# Altium schematic sheet CurrentSensorBoard.SchDoc (continued)

## Library data of the parts
BNC1 = BNC: Manufacturer: TE Connectivity; Manufacturer Part Number: 5227699-1; Body Material: Zinc; Body Plating: Nickel; Frequency: 4GHz; Gender: Female; Impedance: 50R; Insulation Resistance: 5GR; Number of Positions: 1; PCB Mounting Orientation: Vertical; PCB Mount Retention: Without; Polarity: Standard; Sealable: No (Without); Voltage Rating: 500V; Component Kind: Standard; ComponentLink1Description: https://datasheet.ciiva.com/30491/0900766b8121e450-30491606.pdf?src-supplier=RSComponents; ComponentLink1URL: https://datasheet.ciiva.com/30491/0900766b8121e450-30491606.pdf?src-supplier=RSComponents; ComponentLink2Description: https://datasheet.ciiva.com/642/eng-cd-5227699-a1-642820.pdf?src-supplier=Digi-Key; ComponentLink2URL: https://datasheet.ciiva.com/642/eng-cd-5227699-a1-642820.pdf?src-supplier=Digi-Key; ComponentLink3Description: https://datasheet.ciiva.com/8044/1649653-8044086.pdf?src-supplier=Element14; ComponentLink3URL: https://datasheet.ciiva.com/8044/1649653-8044086.pdf?src-supplier=Element14; ComponentLink4Description: https://datasheet.ciiva.com/14565/70042557-14565526.pdf?src-supplier=Allied+Electronics; ComponentLink4URL: https://datasheet.ciiva.com/14565/70042557-14565526.pdf?src-supplier=Allied+Electronics; ComponentLink5Description: https://datasheet.ciiva.com/3131/eng-cs-1307191-bnc-connectors-0307-3131320.pdf?src-supplier=Avnet; ComponentLink5URL: https://datasheet.ciiva.com/3131/eng-cs-1307191-bnc-connectors-0307-3131320.pdf?src-supplier=Avnet; Connector Type: BNC; Contact Material: Bronze; Contact Plating: Silver; Depth: 17.45mm; Digikey Part no.: A33798-ND; ELV: Compliant; Fastening Type: Bayonet; Lead Free: Lead Free; Length: 17.45mm; Library Name: SensorCard.SchLib; Max Frequency: 4GHz; Max Operating Temperature: 85°C; Min Operating Temperature: -55°C; Mount: Through Hole; Orientation: Straight; Package Quantity: 30; Packaging: Rail/Tube; Pricing 1: 1=3.67000, 10=3.52000, 30=3.22700, 60=3.08017, 120=2.93358, 270=2.56685, 510=2.49353, 1020=2.12683, 2520=1.98015 (USD); Radiation Hardening: No; REACH SVHC: Unknown; RoHS: Yes; Stock 1: 1551; Supplier 1: Digi-Key; Supplier Part Number 1: A33798-ND; Termination: Solder
C1-C4 C9 = CMP-2006-03069-1: Manufacturer: Kemet; Dielectric: Y5V; Dielectric Material: Ceramic; Dissipation Factor: 7%; Tolerance: 80%; Voltage: 25V; Case/Package: 0603; Case Code (Imperial): 0603; Case Code (Metric): 1608; Component Kind: Standard; ComponentLink1Description: https://datasheet.ciiva.com/7823/1470417-7823994.pdf?src-supplier=Element14; ComponentLink1URL: https://datasheet.ciiva.com/7823/1470417-7823994.pdf?src-supplier=Element14; ComponentLink2Description: https://datasheet.ciiva.com/46443/1828802-46443708.pdf?src-supplier=Element14; ComponentLink2URL: https://datasheet.ciiva.com/46443/1828802-46443708.pdf?src-supplier=Element14; ComponentLink3Description: https://datasheet.ciiva.com/46443/1828802-46443709.pdf?src-supplier=Element14; ComponentLink3URL: https://datasheet.ciiva.com/46443/1828802-46443709.pdf?src-supplier=Element14; ComponentLink4Description: https://datasheet.ciiva.com/14589/70095383-14589007.pdf?src-supplier=Allied+Electronics; ComponentLink4URL: https://datasheet.ciiva.com/14589/70095383-14589007.pdf?src-supplier=Allied+Electronics; Depth: 0.8mm; Height: 0.8mm; Lead Free: Lead Free; Lead Pitch: 0.7mm; Length: 1.6mm; Library Name: Altium Content Vault; Manufacturer Part Number: C0603C104Z3VACTU; Max Operating Temperature: 85°C; Min Operating Temperature: -30°C; Mount: Surface Mount; Number of Pins: 2; Package Quantity: 1; Packaging: Tape and Reel; Part Number: C0603C104Z3VACTU; Pricing 1: 1=0.10000, 10=0.03500, 100=0.01560, 500=0.01114, 1000=0.00875 (USD); Radiation Hardening: No; REACH SVHC: No SVHC; RoHS: Yes; Stock 1: 1688362; Supplier 1: Digi-Key; Supplier Part Number 1: 399-1100-1-ND; Termination: SMD/SMT; Thickness: 0.034inch; Voltage Rating: 25V; Voltage Rating (DC): 25V; Width: 0.031inch
C5 = CMP-1662-00022-1: Chip Capacitor, 1 nF, +/- 5%, 50 V, -55 to 125 degC, 0603 (1608 Metric), RoHS, Tape and Reel; Manufacturer: Murata; Dielectric Material: Ceramic; Insulation Resistance: 10GR; Resistance: 100000000000 Ohm; Temperature Coefficient: 30ppm/°C; Voltage: 50V; Voltage Rating: 50 V; Case/Package: 0603; Case Code (Imperial): 0603; Case Code (Metric): 1608; Component Kind: Standard; ComponentLink1Description: Manufacturer URL; ComponentLink1URL: http://www.murata.com/; ComponentLink2Description: Datasheet; ComponentLink2URL: http://search.murata.co.jp/Ceramy/image/img/A01X/G101/ENG/GRM1885C1H102JA01-01.pdf; ComponentLink3Description: https://datasheet.ciiva.com/18184/getdatasheetpartid-153109-18184812.pdf?src-supplier=Verical; ComponentLink3URL: https://datasheet.ciiva.com/18184/getdatasheetpartid-153109-18184812.pdf?src-supplier=Verical; ComponentLink4Description: https://datasheet.ciiva.com/29149/450301-da-01-en-vs-kondensator-0603-29149020.pdf?src-supplier=Conrad; ComponentLink4URL: https://datasheet.ciiva.com/29149/450301-da-01-en-vs-kondensator-0603-29149020.pdf?src-supplier=Conrad; ComponentLink5Description: https://datasheet.ciiva.com/5421/product-5421450.pdf?src-supplier=Mouser; ComponentLink5URL: https://datasheet.ciiva.com/5421/product-5421450.pdf?src-supplier=Mouser; ComponentLink6Description: https://datasheet.ciiva.com/131/partnumbering-e-01-131963.pdf?src-supplier=Digi-Key; ComponentLink6URL: https://datasheet.ciiva.com/131/partnumbering-e-01-131963.pdf?src-supplier=Digi-Key; ComponentLink7Description: https://datasheet.ciiva.com/131/partnumbering-e-01-131964.pdf?src-supplier=Digi-Key; ComponentLink7URL: https://datasheet.ciiva.com/131/partnumbering-e-01-131964.pdf?src-supplier=Digi-Key; ComponentLink8Description: https://datasheet.ciiva.com/6072/4360-6072460.pdf?src-supplier=Farnell; ComponentLink8URL: https://datasheet.ciiva.com/6072/4360-6072460.pdf?src-supplier=Farnell; ComponentLink9Description: https://datasheet.ciiva.com/131/partnumbering-e-01-131965.pdf?src-supplier=Digi-Key; ComponentLink9URL: https://datasheet.ciiva.com/131/partnumbering-e-01-131965.pdf?src-supplier=Digi-Key; ComponentLink10Description: https://datasheet.ciiva.com/7828/1784402-7828893.pdf?src-supplier=Element14; ComponentLink10URL: https://datasheet.ciiva.com/7828/1784402-7828893.pdf?src-supplier=Element14; ComponentLink11Description: https://datasheet.ciiva.com/7828/1784402-7828894.pdf?src-supplier=Element14; ComponentLink11URL: https://datasheet.ciiva.com/7828/1784402-7828894.pdf?src-supplier=Element14; ComponentLink12Description: https://datasheet.ciiva.com/7828/4360-7828895.pdf?src-supplier=Element14; ComponentLink12URL: https://datasheet.ciiva.com/7828/4360-7828895.pdf?src-supplier=Element14; DatasheetVersion: Mar-16; Depth: 0.8mm; Height: 0.8 mm; Lead Free: Lead Free; Length: 1.6 mm; Library Name: Altium Content Vault; Manufacturer Part Number: GRM1885C1H102JA01D; Material: Ceramic; Max Operating Temperature: 125degC; Min Operating Temperature: -55degC; Mount: Surface Mount; Number of Pins: 2; PackageDescription: 2-Pin Surface Mount Device, Body 1.6 x 0.8 mm; Package Quantity: 4000; PackageReference: 0603; Packaging: Tape and Reel; Pins: 2; Pricing 1: 1=0.10000, 10=0.07000, 100=0.03300, 500=0.02314, 1000=0.01916 (USD); Radiation Hardening: No; REACH SVHC: No SVHC; RoHS: Yes; RoHSCompliant: True; Stock 1: 1837786; Supplier 1: Digi-Key; Supplier Part Number 1: 490-1451-1-ND; Termination: SMD/SMT; Thickness: 0.035inch; Tolerance: 5%; Type (Capacitors): C0G; Voltage Rating (DC): 50 V; Width: 0.8 mm
C6-C8 C10 C11 = Cap: Capacitor; Manufacturer: Samsung; Manufacturer Part Number: CL21A106KAFN3NE; Tolerance: 10%; Voltage Rating: 25V; Case/Package: 0805; Case Code (Imperial): 0805; Case Code (Metric): 2012; Component Kind: Standard; ComponentLink1Description: https://datasheet.ciiva.com/25720/cl21a106kafn3ne-25720275.pdf?src-supplier=Digi-Key; ComponentLink1URL: https://datasheet.ciiva.com/25720/cl21a106kafn3ne-25720275.pdf?src-supplier=Digi-Key; Lead Free: Lead Free; Length: 0.079inch; Library Name: SensorCard.SchLib; Max Operating Temperature: 85°C; Min Operating Temperature: -55°C; Mount: Surface Mount; Package Quantity: 1; Packaging: Cut Tape; Pricing 1: 1=0.28000, 10=0.19300, 100=0.09770, 500=0.06684, 1000=0.05912 (USD); RoHS: Yes; Stock 1: 51388; Supplier 1: Digi-Key; Supplier Part Number 1: 1276-2890-1-ND; Thickness: 0.053inch; Width: 0.049inch
DS1 = CMP-2000-06622-1: LED Green, 75 mW, 80 mA, -55 to 85 degC, 2-Pin SMD, RoHS, Tape and Reel; Manufacturer: Lite-On; Dominant Wavelength: 576.5nm; Forward (Drive) Current: 5mA; Forward Current: 30mA; Forward Voltage: 2.3V; Intensity: 28mcd; Lens Color: Colorless; Lens Style: Diffused; Lens Transparency: Clear; Number of Elements: 1; Power Dissipation: 75mW; Reverse Voltage: 5V; Viewing Angle: 130°; Case/Package: 0603; Case Code (Imperial): 0603; Case Code (Metric): 1608; Color: Green; Component Kind: Standard; ComponentLink1Description: https://datasheet.ciiva.com/12400/ltst-c193-series-201756-12400795.pdf?src-supplier=Mouser; ComponentLink1URL: https://datasheet.ciiva.com/12400/ltst-c193-series-201756-12400795.pdf?src-supplier=Mouser; ComponentLink2Description: https://datasheet.ciiva.com/11773/0900766b80dc0550-11773612.pdf?src-supplier=RSComponents; ComponentLink2URL: https://datasheet.ciiva.com/11773/0900766b80dc0550-11773612.pdf?src-supplier=RSComponents; ComponentLink3Description: https://datasheet.ciiva.com/16444/ltst-c193kgkt-5a-16444759.pdf?src-supplier=Future+Electronics; ComponentLink3URL: https://datasheet.ciiva.com/16444/ltst-c193kgkt-5a-16444759.pdf?src-supplier=Future+Electronics; ComponentLink4Description: https://datasheet.ciiva.com/2110/s-110-ltst-c193kgkt-5a-2110267.pdf?src-supplier=Digi-Key; ComponentLink4URL: https://datasheet.ciiva.com/2110/s-110-ltst-c193kgkt-5a-2110267.pdf?src-supplier=Digi-Key; ComponentLink5Description: https://datasheet.ciiva.com/2110/s-110-ltst-c193kgkt-5a-2110269.pdf?src-supplier=Digi-Key; ComponentLink5URL: https://datasheet.ciiva.com/2110/s-110-ltst-c193kgkt-5a-2110269.pdf?src-supplier=Digi-Key; ComponentLink6Description: https://datasheet.ciiva.com/2110/s-110-ltst-c193kgkt-5a-2110268.pdf?src-supplier=Digi-Key; ComponentLink6URL: https://datasheet.ciiva.com/2110/s-110-ltst-c193kgkt-5a-2110268.pdf?src-supplier=Digi-Key; Datasheet URL: http://optoelectronics.liteon.com/upload/download/DS22-2007-0094/S_110_LTST-C193KGKT-5A.pdf; Datasheet Version: 03/2007; Depth: 0.8mm; Dimension: 1.6x0.8x0.35mm; Height: 0.35mm; Illumination Color: Green; Lead Free: Lead Free; Length: 1.6mm; Lens Type: Clear; Library Name: Altium Content Vault; Luminous Intensity: 28mcd; Manufacturer Part Number: LTST-C193KGKT-5A; Manufacturer URL: http://www.us.liteon.com/; Max Operating Temperature: 85°C; Min Operating Temperature: -55°C; Mount: Surface Mount; Mounting Technology: SurfaceMount; Number of LEDs: 1; Number of Pins: 2; Package Description: 2-Pin Surface Mount Device, Body 1.6 x 0.8 mm; Package Quantity: 3000; Package Reference: C193KGKT-5A; Packaging: Tape and Reel; Power Rating: 75mW; Pricing 1: 1=0.41000, 10=0.29600, 25=0.22680, 100=0.14800, 250=0.10852, 500=0.09210, 1000=0.07565, 2500=0.06578 (USD); Radiation Hardening: No; RoHS: Yes; Stock 1: 98599; Supplier 1: Digi-Key; Supplier Part Number 1: 160-1828-1-ND; Test Current: 5mA; Width: 0.8mm
DS2 = CMP-2000-06624-1: LED Uni-Color Blue, 76 mW, 20 mA, -20 to 80 degC, 2-Pin SMD, RoHS, Tape and Reel; Brand: Lite-On; Dominant Wavelength: 475nm; Forward Current: 5mA; Forward Voltage: 2.8V; LED Size: 1.6mmx0.8mmx0.35mm; Lens Color: Colorless; Lens Style: Diffused; Lens Transparency: Clear; Luminous Intensity: 15mcd; Number of Elements: 1; Power Dissipation: 76mW; Reverse Voltage: 5V; Viewing Angle: 130deg; Wavelength/Color Temperature: 465nmto475nm; Case/Package: 0603; Case Code (Imperial): 0603; Case Code (Metric): 1608; Color: Blue; Component Kind: Standard; ComponentLink1Description: https://datasheet.ciiva.com/4688/lite-on-ltst-c193tbkt-5a-187386-4688832.pdf?src-supplier=Mouser; ComponentLink1URL: https://datasheet.ciiva.com/4688/lite-on-ltst-c193tbkt-5a-187386-4688832.pdf?src-supplier=Mouser; ComponentLink2Description: https://datasheet.ciiva.com/2111/ltst-c193tbkt-5a-2111219.pdf?src-supplier=Digi-Key; ComponentLink2URL: https://datasheet.ciiva.com/2111/ltst-c193tbkt-5a-2111219.pdf?src-supplier=Digi-Key; ComponentLink3Description: https://datasheet.ciiva.com/15201/getdatasheetpartid-293056-15201395.pdf?src-supplier=Verical; ComponentLink3URL: https://datasheet.ciiva.com/15201/getdatasheetpartid-293056-15201395.pdf?src-supplier=Verical; ComponentLink4Description: https://datasheet.ciiva.com/11773/0900766b80dc0554-11773880.pdf?src-supplier=RSComponents; ComponentLink4URL: https://datasheet.ciiva.com/11773/0900766b80dc0554-11773880.pdf?src-supplier=RSComponents; ComponentLink5Description: https://datasheet.ciiva.com/16210/ltst-c193tbkt-5a-16210824.pdf?src-supplier=Future+Electronics; ComponentLink5URL: https://datasheet.ciiva.com/16210/ltst-c193tbkt-5a-16210824.pdf?src-supplier=Future+Electronics; ComponentLink6Description: https://datasheet.ciiva.com/2111/p-100-ltst-c193tbkt-5a-2111220.pdf?src-supplier=Digi-Key; ComponentLink6URL: https://datasheet.ciiva.com/2111/p-100-ltst-c193tbkt-5a-2111220.pdf?src-supplier=Digi-Key; ComponentLink7Description: https://datasheet.ciiva.com/2111/p-100-ltst-c193tbkt-5a-2111221.pdf?src-supplier=Digi-Key; ComponentLink7URL: https://datasheet.ciiva.com/2111/p-100-ltst-c193tbkt-5a-2111221.pdf?src-supplier=Digi-Key; Datasheet URL: https://datasheet.ciiva.com/15201/getdatasheetpartid-293056-15201395.pdf; Datasheet Version: 08/2011; Depth: 0.8mm; Factory Pack Quantity: 3000; Height: 0.35mm; Illumination Color: Blue; Lead Free: Lead Free; Length: 1.6mm; Library Name: Altium Content Vault; Manufacturer: Lite-On; Manufacturer Part Number: LTST-C193TBKT-5A; Manufacturer URL: http://www.us.liteon.com/; Maximum Operating Temperature: +80C; Max Operating Temperature: 80°C; Minimum Operating Temperature: -20C; Min Operating Temperature: -20°C; Mount: Surface Mount; Mounting Technology: SurfaceMount; Number of LEDs: 1; Number of Pins: 2; Package / Case: 0603; Package Description: 2-Pin Surface Mount Device, Body 0.8 x 1.6 mm; Package Quantity: 1; Package Reference: C193TBKT; Packaging: Reel; Pricing 1: 1=0.47000, 10=0.33600, 25=0.25720, 100=0.16780, 250=0.12304, 500=0.10442, 1000=0.08577, 2500=0.07458 (USD); Product Category: StandardLEDs-SMD; Radiation Hardening: No; RoHS: Details; Stock 1: 234360; Supplier 1: Digi-Key; Supplier Part Number 1: 160-1827-1-ND; Test Current: 5mA; Width: 0.8mm
L1-L4 = CMP-0220-00193-1: SMD EMI Suppression Ferrite Bead WE-CBF, Z = 30 Ohm; Manufacturer: Wurth Electronics; Current Rating: 1A; DC Resistance (DCR): 40mR; Impedance: 30 Ohm; Inductance: 5.6H; Max Current Rating: 3A; Number of Lines: 1; Test Frequency: 100MHz; Tolerance: 25%; Case/Package: SMD/SMT; Case Code (Imperial): 0603; Case Code (Metric): 1608; Component Kind: Standard; ComponentLink1Description: Manufacturer Link; ComponentLink1URL: http://www.we-online.com; ComponentLink2Description: Datasheet; ComponentLink2URL: http://www.we-online.de/katalog/media/pdf/742792609.pdf; ComponentLink3Description: https://datasheet.ciiva.com/22711/1696069-22711408.pdf?src-supplier=Element14; ComponentLink3URL: https://datasheet.ciiva.com/22711/1696069-22711408.pdf?src-supplier=Element14; ComponentLink4Description: https://datasheet.ciiva.com/1013/742792609-1013542.pdf?src-supplier=Digi-Key; ComponentLink4URL: https://datasheet.ciiva.com/1013/742792609-1013542.pdf?src-supplier=Digi-Key; ComponentLink5Description: https://datasheet.ciiva.com/4940/742792609-79267-4940428.pdf?src-supplier=Mouser; ComponentLink5URL: https://datasheet.ciiva.com/4940/742792609-79267-4940428.pdf?src-supplier=Mouser; ComponentLink6Description: https://datasheet.ciiva.com/14634/0900766b80d2ddc1-14634954.pdf?src-supplier=Allied+Electronics; ComponentLink6URL: https://datasheet.ciiva.com/14634/0900766b80d2ddc1-14634954.pdf?src-supplier=Allied+Electronics; ComponentLink7Description: https://datasheet.ciiva.com/11799/0900766b80d2ddc1-11799675.pdf?src-supplier=RSComponents; ComponentLink7URL: https://datasheet.ciiva.com/11799/0900766b80d2ddc1-11799675.pdf?src-supplier=RSComponents; ComponentLink8Description: https://datasheet.ciiva.com/23762/742792609-23762991.pdf?src-supplier=Newark; ComponentLink8URL: https://datasheet.ciiva.com/23762/742792609-23762991.pdf?src-supplier=Newark; ComponentLink9Description: https://datasheet.ciiva.com/1466/1696069-1466420.pdf?src-supplier=Element14; ComponentLink9URL: https://datasheet.ciiva.com/1466/1696069-1466420.pdf?src-supplier=Element14; ComponentLink10Description: https://datasheet.ciiva.com/1013/742792609-1013543.pdf?src-supplier=Digi-Key; ComponentLink10URL: https://datasheet.ciiva.com/1013/742792609-1013543.pdf?src-supplier=Digi-Key; ComponentLink11Description: https://datasheet.ciiva.com/1013/742792609-1013544.pdf?src-supplier=Digi-Key; ComponentLink11URL: https://datasheet.ciiva.com/1013/742792609-1013544.pdf?src-supplier=Digi-Key; Core Material: Ferrite; DatasheetVersion: Dec-2005; Depth: 0.8mm; Height: 0.8mm; Length: 1.6mm; Library Name: Altium Content Vault; Manufacturer Part Number: 742792609; Material: Ferrite; Max DC Current: 3A; Max Operating Temperature: 125°C; Min Operating Temperature: -55°C; Mount: Surface Mount; PackageDescription: Chip Inductor, 2-Leads, Body 1.6 x 0.8 mm; Package Quantity: 1; PackageReference: SMD-0603; PackageVersion: 2009; Packaging: Tape and Reel; Pricing 1: 1=0.17000, 50=0.16800, 100=0.15500, 500=0.14800, 1000=0.14100, 2000=0.13400 (USD); REACH SVHC: Unknown; Resistance: 40mR; RoHS: Yes; Stock 1: 70099; Supplier 1: Digi-Key; Supplier Part Number 1: 732-1591-1-ND; Termination: SMD/SMT; Weight: 0.000141oz; Width: 0.8mm
MH1 MH2 = MOUNT_HOLE: MOUNTING HOLE; Component Kind: Standard; Library Name: SensorCard.SchLib
P1 = HDR1x3: Manufacturer: TE Connectivity; Manufacturer Part Number: 282834-3; Approvals: UL; Closed End: No (Without); Connector Type: Receptacle; Current: 10A; Max Supply Voltage (AC): 150V; Number of Circuits: 3; Number of Levels: 1; Pitch: 2.54mm; Plating: Brass; Screwless Terminal Block: Yes (With); Tail Length: 3.4mm; Thread Size: M1.6; Wire/Cable Gauge: 30AWG; Color: Green; Component Kind: Standard; ComponentLink1Description: https://datasheet.ciiva.com/11757/0900766b80f924bc-11757569.pdf?src-supplier=RSComponents; ComponentLink1URL: https://datasheet.ciiva.com/11757/0900766b80f924bc-11757569.pdf?src-supplier=RSComponents; ComponentLink2Description: https://datasheet.ciiva.com/15169/getdatasheetpartid-155865-15169559.pdf?src-supplier=Verical; ComponentLink2URL: https://datasheet.ciiva.com/15169/getdatasheetpartid-155865-15169559.pdf?src-supplier=Verical; ComponentLink3Description: https://datasheet.ciiva.com/30217/eng-cs-1308389-eurostyle-terminal-blocks-0607-30217495.pdf?src-supplier=Onlinecomponents.com; ComponentLink3URL: https://datasheet.ciiva.com/30217/eng-cs-1308389-eurostyle-terminal-blocks-0607-30217495.pdf?src-supplier=Onlinecomponents.com; ComponentLink4Description: https://datasheet.ciiva.com/823/eng-cd-282834-c1-823464.pdf?src-supplier=Digi-Key; ComponentLink4URL: https://datasheet.ciiva.com/823/eng-cd-282834-c1-823464.pdf?src-supplier=Digi-Key; ComponentLink5Description: https://datasheet.ciiva.com/14610/70087777-14610266.pdf?src-supplier=Allied+Electronics; ComponentLink5URL: https://datasheet.ciiva.com/14610/70087777-14610266.pdf?src-supplier=Allied+Electronics; ComponentLink6Description: https://datasheet.ciiva.com/3141/eng-cs-1308389-eurostyle-terminal-blocks-0607-3141116.pdf?src-supplier=Avnet; ComponentLink6URL: https://datasheet.ciiva.com/3141/eng-cs-1308389-eurostyle-terminal-blocks-0607-3141116.pdf?src-supplier=Avnet; ComponentLink7Description: https://datasheet.ciiva.com/16469/eng-cs-1308389-eurostyle-terminal-blocks-0607-16469502.pdf?src-supplier=Avnet+Asia; ComponentLink7URL: https://datasheet.ciiva.com/16469/eng-cs-1308389-eurostyle-terminal-blocks-0607-16469502.pdf?src-supplier=Avnet+Asia; ComponentLink8Description: https://datasheet.ciiva.com/7978/583107-7978559.pdf?src-supplier=Element14; ComponentLink8URL: https://datasheet.ciiva.com/7978/583107-7978559.pdf?src-supplier=Element14; Contact Material: Brass; Contact Plating: Tin; Current Rating: 10A; Depth: 6.5mm; ELV: Compliant; Flammability Rating: UL94 V-0; Housing Color: Green; Housing Material: Polyamide; Interlock: No (Without); Lead Free: Lead Free; Length: 8.08mm; Library Name: SensorCard.SchLib; Max Current Rating: 10A; Max Operating Temperature: 105°C; Max Voltage Rating (AC): 150V; Min Operating Temperature: -40°C; Mount: Through Hole; Number of Contacts: 3; Number of Poles: 3; Number of Positions: 3; Number of Positions Per Level: 3; Number of Rows: 1; Orientation: Straight; Package Quantity: 200; Packaging: Bulk; Pricing 1: 1=2.01000, 10=1.52300, 25=1.41160, 50=1.26920, 100=1.23820, 250=1.08340, 500=0.99052, 1000=0.89767, 5000=0.78933 (USD); Radiation Hardening: No; REACH SVHC: Unknown; RoHS: Yes; Screw Flange: No (Without); Side Stackable: Yes (With); Stock 1: 4635; Supplier 1: Digi-Key; Supplier Part Number 1: A98334-ND; Termination: Solder; Voltage: 150V; Voltage Rating: 150V; Width: 10mm; Wire Gauge (Max): 30AWG; Wire Gauge (Min): 30AWG
P2 = HDR1X7: Manufacturer: TE Connectivity; Manufacturer Part Number: 5-534237-5; Approvals: CSA; Boss: No (Without); Connector Type: Receptacle; Contact Current Rating: 2A; Contact Post Length: 2.92mm; Contact Resistance: 12MR; High Temperature Compatible: No; Insulation Resistance: 5GR; Number of Contacts: 7; PCB Mounting Orientation: Vertical; PCB Mount Retention: Without; PCB Thickness: 2.4mm; Pitch: 2.54mm; Row Spacing: 0.1inch; Stackable: Yes; Voltage Rating: 750V; Color: Black; Component Kind: Standard; ComponentLink1Description: https://datasheet.ciiva.com/16318/eng-cs-1307819-section-5-0908-16318099.pdf?src-supplier=Future+Electronics; ComponentLink1URL: https://datasheet.ciiva.com/16318/eng-cs-1307819-section-5-0908-16318099.pdf?src-supplier=Future+Electronics; ComponentLink2Description: https://datasheet.ciiva.com/15175/getdatasheetpartid-36747-15175462.pdf?src-supplier=Verical; ComponentLink2URL: https://datasheet.ciiva.com/15175/getdatasheetpartid-36747-15175462.pdf?src-supplier=Verical; ComponentLink3Description: https://datasheet.ciiva.com/746/eng-cd-534237-r5-746279.pdf?src-supplier=Digi-Key; ComponentLink3URL: https://datasheet.ciiva.com/746/eng-cd-534237-r5-746279.pdf?src-supplier=Digi-Key; ComponentLink4Description: https://datasheet.ciiva.com/9479/eng-ds-1307819-ampmodu-0811-9479736.pdf?src-supplier=Avnet; ComponentLink4URL: https://datasheet.ciiva.com/9479/eng-ds-1307819-ampmodu-0811-9479736.pdf?src-supplier=Avnet; ComponentLink5Description: https://datasheet.ciiva.com/30056/eng-ds-1307819-ampmodu-0811-30056440.pdf?src-supplier=Onlinecomponents.com; ComponentLink5URL: https://datasheet.ciiva.com/30056/eng-ds-1307819-ampmodu-0811-30056440.pdf?src-supplier=Onlinecomponents.com; Contact Material: Bronze; Contact Plating: Gold; Contact Shape: Square; Current Rating: 2A; Depth: 3.56mm; ELV: Compliant; Flammability Rating: UL94 V-0; Gender: Receptacle; Height: 3.56mm; Housing Color: Black; Housing Material: Polyester; Lead Free: Lead Free; Length: 17.78mm; Library Name: SensorCard.SchLib; Max Operating Temperature: 105°C; Min Operating Temperature: -65°C; Mount: Through Hole; Number of Positions: 7; Number of Rows: 1; Orientation: Straight; Package Quantity: 32; Packaging: Rail/Tube; Pricing 1: 1=2.35000, 32=2.00094, 128=1.82703, 512=1.52250, 1024=1.30500, 5024=1.15710 (USD); Radiation Hardening: No; REACH SVHC: Unknown; RoHS: Yes; Sealable: No (Without); Stock 1: 2592; Supplier 1: Digi-Key; Supplier Part Number 1: A32908-ND; Tail Length: 2.92mm; Termination: Solder
P3 = HDR1x3: Manufacturer: TE Connectivity; Manufacturer Part Number: 215297-3; Connector Type: Receptacle; Contact Current Rating: 3A; Contact Post Length: 3.1mm; Contact Resistance: 12mR; Number of Contacts: 3; PCB Mounting Orientation: Vertical; PCB Mount Retention: With; PCB Thickness: 1.6mm; Pitch: 2.54mm; Sealable: No (Without); Stackable: Yes; Color: Green; Component Kind: Standard; ComponentLink1Description: https://datasheet.ciiva.com/32373/2152973-32373113.pdf?src-supplier=Onlinecomponents.com; ComponentLink1URL: https://datasheet.ciiva.com/32373/2152973-32373113.pdf?src-supplier=Onlinecomponents.com; ComponentLink2Description: https://datasheet.ciiva.com/11748/0900766b805762f6-11748764.pdf?src-supplier=RSComponents; ComponentLink2URL: https://datasheet.ciiva.com/11748/0900766b805762f6-11748764.pdf?src-supplier=RSComponents; ComponentLink3Description: https://datasheet.ciiva.com/8003/525120-8003961.pdf?src-supplier=Element14; ComponentLink3URL: https://datasheet.ciiva.com/8003/525120-8003961.pdf?src-supplier=Element14; ComponentLink4Description: https://datasheet.ciiva.com/6857/eng-cd-215297-d-basefilename-6857450.pdf?src-supplier=Digi-Key; ComponentLink4URL: https://datasheet.ciiva.com/6857/eng-cd-215297-d-basefilename-6857450.pdf?src-supplier=Digi-Key; ComponentLink5Description: https://datasheet.ciiva.com/1439/1651969-1439469.pdf?src-supplier=Farnell; ComponentLink5URL: https://datasheet.ciiva.com/1439/1651969-1439469.pdf?src-supplier=Farnell; ComponentLink6Description: https://datasheet.ciiva.com/14120/215297serie-eng-tds-14120829.pdf?src-supplier=Distrelec; ComponentLink6URL: https://datasheet.ciiva.com/14120/215297serie-eng-tds-14120829.pdf?src-supplier=Distrelec; Contact Gender: Female; Contact Material: Bronze; Contact Plating: Gold; Contact Shape: Square; Current Rating: 3A; Depth: 2.52mm; ELV: Compliant; Flammability Rating: UL94 V-0; Gender: Receptacle; Height: 7mm; Housing Color: Black; Housing Material: Polyester; Length: 7.62mm; Library Name: SensorCard.SchLib; Max Operating Temperature: 105°C; Min Operating Temperature: -55°C; Mount: Through Hole; Number of Positions: 3; Number of Rows: 1; Orientation: Straight; Package Quantity: 240; Packaging: Tray; Pricing 1: 1=0.81000, 10=0.71400, 100=0.61600, 500=0.50400, 1000=0.44800, 5000=0.39200, 10000=0.37240 (USD); RoHS: Yes; Stock 1: 7420; Supplier 1: Digi-Key; Supplier Part Number 1: A106650-ND; Tail Length: 3.1mm; Termination: Solder
P5 P6 = Screw terminal: 6 Pin Screw Terminal, Power Tap 10-32 Through Hole; Manufacturer: Keystone Electronics; Manufacturer Part Number: 8196; Connector Type: PCB; Current Rating: 30A; Gender: Female; Insulation: Non-Insulated; Number of Contacts: 6; Number of Ports: 1; Wire Gauge (Max): 12AWG; Wire Gauge (Min): 22AWG; Component Kind: Standard; ComponentLink1Description: https://datasheet.ciiva.com/16216/m60-2p63-16216147.pdf?src-supplier=Future+Electronics; ComponentLink1URL: https://datasheet.ciiva.com/16216/m60-2p63-16216147.pdf?src-supplier=Future+Electronics; ComponentLink2Description: https://datasheet.ciiva.com/13540/8196-290223-13540281.pdf?src-supplier=Mouser; ComponentLink2URL: https://datasheet.ciiva.com/13540/8196-290223-13540281.pdf?src-supplier=Mouser; ComponentLink3Description: https://datasheet.ciiva.com/22649/1910515-22649575.pdf?src-supplier=Element14; ComponentLink3URL: https://datasheet.ciiva.com/22649/1910515-22649575.pdf?src-supplier=Element14; ComponentLink4Description: https://datasheet.ciiva.com/14551/70181563-14551004.pdf?src-supplier=Allied+Electronics; ComponentLink4URL: https://datasheet.ciiva.com/14551/70181563-14551004.pdf?src-supplier=Allied+Electronics; Contact Material: Brass; Contact Plating: Tin; Depth: 11.93mm; Height: 0.679inch; Lead Free: Lead Free; Length: 11.93mm; Library Name: SensorCard.SchLib; Material: Brass; Max Current Rating: 30A; Mount: Through Hole; Number of Pins: 6; Number of Rows: 2; Number of Terminals: 6; Orientation: Straight; Package Quantity: 10; Packaging: Bulk; Pricing 1: 1=0.89000, 10=0.78100, 50=0.70400, 100=0.69840, 250=0.61220, 1000=0.54860, 2500=0.45920, 5000=0.42854 (USD); REACH SVHC: No SVHC; RoHS: Yes; Stock 1: 45944; Supplier 1: Digi-Key; Supplier Part Number 1: 36-8196-ND; Terminal Material: Brass; Termination: Screw
R1 R3 = CMP-2000-00767-1: Manufacturer: Panasonic; Manufacturer Part Number: ERJ3GEYJ302V; Max Power Dissipation: 100mW; Temperature Coefficient: 200ppm/°C; Tolerance: 5%; Voltage Rating: 75V; Case/Package: 0603; Case Code (Imperial): 0603; Case Code (Metric): 1608; Component Kind: Standard; ComponentLink1Description: https://datasheet.ciiva.com/11821/0900766b80f806dd-11821475.pdf?src-supplier=RSComponents; ComponentLink1URL: https://datasheet.ciiva.com/11821/0900766b80f806dd-11821475.pdf?src-supplier=RSComponents; ComponentLink2Description: https://datasheet.ciiva.com/24027/aoa0000ce1-37422-24027397.pdf?src-supplier=Mouser; ComponentLink2URL: https://datasheet.ciiva.com/24027/aoa0000ce1-37422-24027397.pdf?src-supplier=Mouser; ComponentLink3Description: https://datasheet.ciiva.com/43460/1500035-43460725.pdf?src-supplier=Farnell; ComponentLink3URL: https://datasheet.ciiva.com/43460/1500035-43460725.pdf?src-supplier=Farnell; ComponentLink4Description: https://datasheet.ciiva.com/9196/aoa0000ce1-9196743.pdf?src-supplier=Avnet; ComponentLink4URL: https://datasheet.ciiva.com/9196/aoa0000ce1-9196743.pdf?src-supplier=Avnet; ComponentLink5Description: https://datasheet.ciiva.com/16786/70255313-16786823.pdf?src-supplier=Allied+Electronics; ComponentLink5URL: https://datasheet.ciiva.com/16786/70255313-16786823.pdf?src-supplier=Allied+Electronics; ComponentLink6Description: https://datasheet.ciiva.com/9196/aoa0000ce1-9196746.pdf?src-supplier=Avnet; ComponentLink6URL: https://datasheet.ciiva.com/9196/aoa0000ce1-9196746.pdf?src-supplier=Avnet; ComponentLink7Description: https://datasheet.ciiva.com/46386/1500035-46386731.pdf?src-supplier=Element14; ComponentLink7URL: https://datasheet.ciiva.com/46386/1500035-46386731.pdf?src-supplier=Element14; ComponentLink8Description: https://datasheet.ciiva.com/30196/4aoa0000ce1-30196300.pdf?src-supplier=Onlinecomponents.com; ComponentLink8URL: https://datasheet.ciiva.com/30196/4aoa0000ce1-30196300.pdf?src-supplier=Onlinecomponents.com; ComponentLink9Description: https://datasheet.ciiva.com/28565/1678796-28565150.pdf?src-supplier=Element14; ComponentLink9URL: https://datasheet.ciiva.com/28565/1678796-28565150.pdf?src-supplier=Element14; ComponentLink10Description: https://datasheet.ciiva.com/28691/1678796-28691966.pdf?src-supplier=Element14; ComponentLink10URL: https://datasheet.ciiva.com/28691/1678796-28691966.pdf?src-supplier=Element14; Composition: Thick Film; Contact Plating: Tin; Depth: 0.8mm; Features: Automotive AEC-Q200; Height: 0.45mm; Length: 1.6mm; Library Name: Altium Content Vault; Max Operating Temperature: 155°C; Military Standard: Not; Min Operating Temperature: -55°C; Mount: Surface Mount; Number of Terminations: 2; Package Quantity: 1; Packaging: Tape and Reel; Part Number: ERJ-3GEYJ302V; Power Rating: 100mW; Pricing 1: 1=0.10000, 10=0.04300, 100=0.01760, 1000=0.00791, 2500=0.00686 (USD); REACH SVHC: No SVHC; RoHS: Yes; Stock 1: 269975; Supplier 1: Digi-Key; Supplier Part Number 1: P3.0KGCT-ND; Termination: SMD/SMT; Width: 0.031inch
R2 = CMP-1659-00035-1: General Purpose Chip Resistor, 470 Ohm, +/- 1%, -55 to 155 degC, 0603 (1608 Metric), RoHS, Tape and Reel; Manufacturer: Yageo; Max Power Dissipation: 100mW; Resistance: 470; Temperature Coefficient: 100ppm/°C; Voltage Rating: 50V; Working Voltage: 75V; Case/Package: 0603; Case Code (Imperial): 0603; Case Code (Metric): 1608; Component Kind: Standard; ComponentLink1Description: Manufacturer URL; ComponentLink1URL: http://www.yageo.com/; ComponentLink2Description: Datasheet; ComponentLink2URL: https://datasheet.ciiva.com/11808/0900766b80f96399-11808177.pdf; ComponentLink3Description: https://datasheet.ciiva.com/11808/0900766b80f96399-11808177.pdf?src-supplier=RSComponents; ComponentLink3URL: https://datasheet.ciiva.com/11808/0900766b80f96399-11808177.pdf?src-supplier=RSComponents; ComponentLink4Description: https://datasheet.ciiva.com/7746/1738695-7746359.pdf?src-supplier=Element14; ComponentLink4URL: https://datasheet.ciiva.com/7746/1738695-7746359.pdf?src-supplier=Element14; ComponentLink5Description: https://datasheet.ciiva.com/7746/1738695-7746360.pdf?src-supplier=Element14; ComponentLink5URL: https://datasheet.ciiva.com/7746/1738695-7746360.pdf?src-supplier=Element14; ComponentLink6Description: https://datasheet.ciiva.com/7660/1868787-7660793.pdf?src-supplier=Element14; ComponentLink6URL: https://datasheet.ciiva.com/7660/1868787-7660793.pdf?src-supplier=Element14; ComponentLink7Description: https://datasheet.ciiva.com/46440/1868787-46440907.pdf?src-supplier=Element14; ComponentLink7URL: https://datasheet.ciiva.com/46440/1868787-46440907.pdf?src-supplier=Element14; ComponentLink8Description: https://datasheet.ciiva.com/2454/pyu-r-int-thick-7-2454012.pdf?src-supplier=Digi-Key; ComponentLink8URL: https://datasheet.ciiva.com/2454/pyu-r-int-thick-7-2454012.pdf?src-supplier=Digi-Key; ComponentLink9Description: https://datasheet.ciiva.com/2454/pyu-r-int-thick-7-2454013.pdf?src-supplier=Digi-Key; ComponentLink9URL: https://datasheet.ciiva.com/2454/pyu-r-int-thick-7-2454013.pdf?src-supplier=Digi-Key; ComponentLink10Description: https://datasheet.ciiva.com/2454/pyu-r-int-thick-7-2454014.pdf?src-supplier=Digi-Key; ComponentLink10URL: https://datasheet.ciiva.com/2454/pyu-r-int-thick-7-2454014.pdf?src-supplier=Digi-Key; Composition: Thick Film; Depth: 0.8mm; Features: Moisture Resistant; Height: 0.022inch; Lead Free: Lead Free; Length: 1.6mm; Library Name: Altium Content Vault; Manufacturer Part Number: RC0603FR-07470RL; Max Operating Temperature: 155°C; Military Standard: Not; Min Operating Temperature: -55°C; Number of Terminations: 2; Package Quantity: 1; Packaging: Tape and Reel; Power Rating: 100mW; Pricing 1: 1=0.10000, 10=0.02400, 100=0.00970, 1000=0.00437, 2500=0.00379 (USD); REACH SVHC: No SVHC; RoHS: Yes; Stock 1: 7151461; Supplier 1: Digi-Key; Supplier Part Number 1: 311-470HRCT-ND; Termination: SMD/SMT; Tolerance: 1%; Width: 0.031inch
R4 = CMP-2000-00874-1: Manufacturer: Panasonic; Part Number: ERA-3AEB103V; Max Power Dissipation: 100mW; Temperature Coefficient: 25ppm/°C; Voltage Rating: 75V; Case/Package: 0603; Case Code (Imperial): 0603; Case Code (Metric): 1608; Component Kind: Standard; ComponentLink1Description: https://datasheet.ciiva.com/5734/485442-5734528.pdf?src-supplier=Farnell; ComponentLink1URL: https://datasheet.ciiva.com/5734/485442-5734528.pdf?src-supplier=Farnell; ComponentLink2Description: https://datasheet.ciiva.com/11808/0900766b80fe20f7-11808028.pdf?src-supplier=RSComponents; ComponentLink2URL: https://datasheet.ciiva.com/11808/0900766b80fe20f7-11808028.pdf?src-supplier=RSComponents; ComponentLink3Description: https://datasheet.ciiva.com/29183/451250-da-01-en-widerstand-0603-era-29183809.pdf?src-supplier=Conrad; ComponentLink3URL: https://datasheet.ciiva.com/29183/451250-da-01-en-widerstand-0603-era-29183809.pdf?src-supplier=Conrad; ComponentLink4Description: https://datasheet.ciiva.com/9194/aoa0000ce26-9194213.pdf?src-supplier=Avnet; ComponentLink4URL: https://datasheet.ciiva.com/9194/aoa0000ce26-9194213.pdf?src-supplier=Avnet; ComponentLink5Description: https://datasheet.ciiva.com/46376/485442-46376357.pdf?src-supplier=Element14; ComponentLink5URL: https://datasheet.ciiva.com/46376/485442-46376357.pdf?src-supplier=Element14; ComponentLink6Description: https://datasheet.ciiva.com/17212/aoa0000ce26-17212070.pdf?src-supplier=Avnet+Europe; ComponentLink6URL: https://datasheet.ciiva.com/17212/aoa0000ce26-17212070.pdf?src-supplier=Avnet+Europe; ComponentLink7Description: https://datasheet.ciiva.com/46422/1776116-46422520.pdf?src-supplier=Element14; ComponentLink7URL: https://datasheet.ciiva.com/46422/1776116-46422520.pdf?src-supplier=Element14; ComponentLink8Description: https://datasheet.ciiva.com/16427/aoa0000ce26-16427097.pdf?src-supplier=Future+Electronics; ComponentLink8URL: https://datasheet.ciiva.com/16427/aoa0000ce26-16427097.pdf?src-supplier=Future+Electronics; ComponentLink9Description: https://datasheet.ciiva.com/24072/aoa0000ce26-197354-24072585.pdf?src-supplier=Mouser; ComponentLink9URL: https://datasheet.ciiva.com/24072/aoa0000ce26-197354-24072585.pdf?src-supplier=Mouser; ComponentLink10Description: https://datasheet.ciiva.com/46388/1776116-46388109.pdf?src-supplier=Element14; ComponentLink10URL: https://datasheet.ciiva.com/46388/1776116-46388109.pdf?src-supplier=Element14; ComponentLink11Description: https://datasheet.ciiva.com/30126/54aoa0000ce26-30126478.pdf?src-supplier=Onlinecomponents.com; ComponentLink11URL: https://datasheet.ciiva.com/30126/54aoa0000ce26-30126478.pdf?src-supplier=Onlinecomponents.com; ComponentLink12Description: https://datasheet.ciiva.com/46388/485442-46388110.pdf?src-supplier=Element14; ComponentLink12URL: https://datasheet.ciiva.com/46388/485442-46388110.pdf?src-supplier=Element14; ComponentLink13Description: https://datasheet.ciiva.com/5734/aoa0000ce26-5734527.pdf?src-supplier=Farnell; ComponentLink13URL: https://datasheet.ciiva.com/5734/aoa0000ce26-5734527.pdf?src-supplier=Farnell; Composition: Thin Film; Contact Plating: Tin; Depth: 0.8mm; Height: 0.45mm; Lead Free: Lead Free; Length: 1.6mm; Library Name: Altium Content Vault; Manufacturer Part Number: ERA3AEB103V; Max Operating Temperature: 155°C; Military Standard: Not; Min Operating Temperature: -55°C; Mount: Surface Mount; Number of Pins: 2; Number of Terminations: 2; Package Quantity: 5000; Packaging: Tape and Reel; Power Rating: 100mW; Pricing 1: 1=0.35000, 10=0.30000, 100=0.11720, 1000=0.04906, 2500=0.04498 (USD); Radiation Hardening: No; REACH SVHC: No SVHC; RoHS: Yes; Stock 1: 912546; Supplier 1: Digi-Key; Supplier Part Number 1: P10KDBCT-ND; Termination: SMD/SMT; Voltage Rating (DC): 75V; Width: 0.8mm
R5 = Res 0603: Resistor; Manufacturer: Stackpole Electronics; Manufacturer Part Number: RHC2512FT150R; Resistance: 150; Temperature Coefficient: 100ppm/°C; Case/Package: 2512; Case Code (Imperial): 2512; Case Code (Metric): 6432; Component Kind: Standard; ComponentLink1Description: https://www.seielect.com/Catalog/SEI-rhc.pdf?src-supplier=Avnet; ComponentLink1URL: https://www.seielect.com/Catalog/SEI-rhc.pdf?src-supplier=Avnet; ComponentLink2Description: https://www.seielect.com/catalog/SEI-Packaging.pdf?src-supplier=Digi-Key; ComponentLink2URL: https://www.seielect.com/catalog/SEI-Packaging.pdf?src-supplier=Digi-Key; Composition: Thick Film; Contact Plating: Tin; Features: Moisture Resistant; Height: 0.028inch; Lead Free: Lead Free; Length: 0.248inch; Library Name: SensorCard.SchLib; Max Operating Temperature: 155°C; Min Operating Temperature: -55°C; Mount: Surface Mount; Number of Terminations: 2; Packaging: Tape and Reel; Power Rating: 2W; Pricing 1: 1=0.88000, 10=0.76200, 100=0.53130, 1000=0.31185 (USD); Radiation Hardening: No; RoHS: Yes; Stock 1: 27233; Supplier 1: Digi-Key; Supplier Part Number 1: RHC2512FT150RCT-ND; Width: 0.126inch
R6 = CMP-2000-00133-1: Manufacturer: Panasonic; Manufacturer Part Number: ERA-3AEB1072V; Max Power Dissipation: 100mW; Temperature Coefficient: 25ppm/°C; Voltage Rating: 75V; Case/Package: 0603; Case Code (Imperial): 0603; Case Code (Metric): 1608; Component Kind: Standard; ComponentLink1Description: https://datasheet.ciiva.com/11814/0900766b80eb1d8c-11814904.pdf?src-supplier=RSComponents; ComponentLink1URL: https://datasheet.ciiva.com/11814/0900766b80eb1d8c-11814904.pdf?src-supplier=RSComponents; ComponentLink2Description: https://datasheet.ciiva.com/29183/452217-da-01-en-widerstand-0603-era-29183962.pdf?src-supplier=Conrad; ComponentLink2URL: https://datasheet.ciiva.com/29183/452217-da-01-en-widerstand-0603-era-29183962.pdf?src-supplier=Conrad; ComponentLink3Description: https://datasheet.ciiva.com/7829/485442-7829094.pdf?src-supplier=Element14; ComponentLink3URL: https://datasheet.ciiva.com/7829/485442-7829094.pdf?src-supplier=Element14; ComponentLink4Description: https://datasheet.ciiva.com/9194/aoa0000ce26-9194486.pdf?src-supplier=Avnet; ComponentLink4URL: https://datasheet.ciiva.com/9194/aoa0000ce26-9194486.pdf?src-supplier=Avnet; ComponentLink5Description: https://datasheet.ciiva.com/7829/485442-7829095.pdf?src-supplier=Element14; ComponentLink5URL: https://datasheet.ciiva.com/7829/485442-7829095.pdf?src-supplier=Element14; ComponentLink6Description: https://datasheet.ciiva.com/5971/485442-5971785.pdf?src-supplier=Farnell; ComponentLink6URL: https://datasheet.ciiva.com/5971/485442-5971785.pdf?src-supplier=Farnell; ComponentLink7Description: https://datasheet.ciiva.com/17212/aoa0000ce26-17212265.pdf?src-supplier=Avnet+Europe; ComponentLink7URL: https://datasheet.ciiva.com/17212/aoa0000ce26-17212265.pdf?src-supplier=Avnet+Europe; ComponentLink8Description: https://datasheet.ciiva.com/5971/485442-5971787.pdf?src-supplier=Farnell; ComponentLink8URL: https://datasheet.ciiva.com/5971/485442-5971787.pdf?src-supplier=Farnell; ComponentLink9Description: https://datasheet.ciiva.com/5971/485442-5971789.pdf?src-supplier=Farnell; ComponentLink9URL: https://datasheet.ciiva.com/5971/485442-5971789.pdf?src-supplier=Farnell; ComponentLink10Description: https://datasheet.ciiva.com/5971/485442-5971791.pdf?src-supplier=Farnell; ComponentLink10URL: https://datasheet.ciiva.com/5971/485442-5971791.pdf?src-supplier=Farnell; Composition: Metal Film; Contact Plating: Tin; Depth: 0.8mm; Features: Automotive AEC-Q200; Height: 0.45mm; Lead Free: Lead Free; Length: 1.6mm; Library Name: Altium Content Vault; Max Operating Temperature: 155°C; Military Standard: Not; Min Operating Temperature: -55°C; Mount: Surface Mount; Number of Pins: 2; Number of Terminations: 2; Package Quantity: 5000; Packaging: Tape and Reel; Part Number: ERA-3AEB1072V; Power Rating: 100mW; Pricing 1: 1=0.35000, 10=0.30000, 100=0.11720, 1000=0.04906, 2500=0.04498 (USD); Radiation Hardening: No; REACH SVHC: No SVHC; RoHS: Yes; Stock 1: 22170; Supplier 1: Digi-Key; Voltage Rating (DC): 75V; Width: 0.8mm
R8 = CMP-2000-00068-1: Manufacturer: Panasonic; Manufacturer Part Number: ERA-3AEB4121V; Max Power Dissipation: 100mW; Temperature Coefficient: 25ppm/°C; Voltage Rating: 75V; Case/Package: 0603; Case Code (Imperial): 0603; Case Code (Metric): 1608; Component Kind: Standard; ComponentLink1Description: https://datasheet.ciiva.com/11810/0900766b80eb1d8c-11810059.pdf?src-supplier=RSComponents; ComponentLink1URL: https://datasheet.ciiva.com/11810/0900766b80eb1d8c-11810059.pdf?src-supplier=RSComponents; ComponentLink2Description: https://datasheet.ciiva.com/7763/485442-7763493.pdf?src-supplier=Element14; ComponentLink2URL: https://datasheet.ciiva.com/7763/485442-7763493.pdf?src-supplier=Element14; ComponentLink3Description: https://datasheet.ciiva.com/5971/485442-5971784.pdf?src-supplier=Farnell; ComponentLink3URL: https://datasheet.ciiva.com/5971/485442-5971784.pdf?src-supplier=Farnell; ComponentLink4Description: https://datasheet.ciiva.com/9195/aoa0000ce26-9195720.pdf?src-supplier=Avnet; ComponentLink4URL: https://datasheet.ciiva.com/9195/aoa0000ce26-9195720.pdf?src-supplier=Avnet; ComponentLink5Description: https://datasheet.ciiva.com/24062/aoa0000ce26-32660-24062713.pdf?src-supplier=Mouser; ComponentLink5URL: https://datasheet.ciiva.com/24062/aoa0000ce26-32660-24062713.pdf?src-supplier=Mouser; ComponentLink6Description: https://datasheet.ciiva.com/5971/485442-5971788.pdf?src-supplier=Farnell; ComponentLink6URL: https://datasheet.ciiva.com/5971/485442-5971788.pdf?src-supplier=Farnell; ComponentLink7Description: https://datasheet.ciiva.com/17213/aoa0000ce26-17213229.pdf?src-supplier=Avnet+Europe; ComponentLink7URL: https://datasheet.ciiva.com/17213/aoa0000ce26-17213229.pdf?src-supplier=Avnet+Europe; Composition: Metal Film; Contact Plating: Tin; Depth: 0.8mm; Features: Automotive AEC-Q200; Height: 0.45mm; Lead Free: Lead Free; Length: 1.6mm; Library Name: Altium Content Vault; Max Operating Temperature: 155°C; Military Standard: Not; Min Operating Temperature: -55°C; Mount: Surface Mount; Number of Pins: 2; Number of Terminations: 2; Package Quantity: 5000; Packaging: Tape and Reel; Part Number: ERA-3AEB4121V; Power Rating: 100mW; Pricing 1: 1=0.35000, 10=0.30000, 100=0.11730, 1000=0.04908, 2500=0.04499 (USD); Radiation Hardening: No; REACH SVHC: No SVHC; RoHS: Yes; Stock 1: 47375; Supplier 1: Digi-Key; Supplier Part Number 1: P4.12KDBCT-ND; Termination: SMD/SMT; Voltage Rating (DC): 75V; Width: 0.8mm
TP1-TP7 = CMP-2000-05575-1: Test Point, Yellow, 1-Pin THD, RoHS, Bulk; Manufacturer: Keystone; Body Material: PBT; Inside Diameter: 1.27mm; Number of Ports: 1; Outside Diameter: 2.54mm; Plating: Silver; Color: Yellow; Component Kind: Standard; Contact Material: Bronze; Contact Plating: Silver; Datasheet URL: http://www.keyelco.com/product-pdf.cfm?p=1313; Datasheet Version: Rev. G, 12/2008; Flammability Rating: UL94 V-0; Height: 0.3inch; Housing Color: Yellow; Lead Free: Lead Free; Length: 7.62mm; Library Name: Altium Content Vault; Manufacturer Part Number: 5004; Manufacturer URL: http://www.keyelco.com/; Material: Nylon; Mount: Through Hole; Mounting Technology: ThroughHole; Orientation: Straight; Package Description: 1-Pin Through Hole Device, Dia 2.54 mm; Package Quantity: 10; Package Reference: 5004; Packaging: Bulk; Pricing 1: 1=0.35000, 10=0.33500, 50=0.24380, 250=0.21032, 1000=0.17140, 2500=0.15296, 5000=0.14340 (USD); REACH SVHC: No SVHC; RoHS: Yes; Stock 1: 173022; Supplier 1: Digi-Key; Supplier Part Number 1: 36-5004-ND
U1 = ADS8860: IC ADC 16BIT 1MSPS 10VSSOP; Manufacturer: Texas Instruments; Integral Nonlinearity (INL): 2LSB; Interface: SPI; Max Power Dissipation: 7.2mW; Max Supply Voltage: 3.6V; Min Supply Voltage: 2.7V; Nominal Supply Current: 1.8mA; Number of A/D Converters: 1; Number of Bits: 16; Polarity: Unipolar; Power Consumption: 5.5mW; Resolution: 16b; Sampling Rate: 1MS/s; Signal to Noise Ratio (SNR): 93dB; Supply Type: Analog; Case/Package: VSSOP; Component Kind: Standard; ComponentLink1Description: http://www.ti.com/lit/pdf/slyb174?src-supplier=Digi-Key; ComponentLink1URL: http://www.ti.com/lit/pdf/slyb174?src-supplier=Digi-Key; ComponentLink2Description: http://www.ti.com/general/docs/lit/getliterature.tsp?genericPartNumber=ADS8860&amp;&amp;fileType=pdf&src-supplier=Farnell; ComponentLink2URL: http://www.ti.com/general/docs/lit/getliterature.tsp?genericPartNumber=ADS8860&amp;&amp;fileType=pdf&src-supplier=Farnell; ComponentLink3Description: http://www.ti.com/lit/ds/symlink/ads8860.pdf?src-supplier=Digi-Key; ComponentLink3URL: http://www.ti.com/lit/ds/symlink/ads8860.pdf?src-supplier=Digi-Key; ComponentLink4Description: http://www.ti.com/general/docs/lit/getliterature.tsp?genericPartNumber=ADS8860&&fileType=pdf&src-supplier=Avnet; ComponentLink4URL: http://www.ti.com/general/docs/lit/getliterature.tsp?genericPartNumber=ADS8860&&fileType=pdf&src-supplier=Avnet; ComponentLink5Description: http://www.farnell.com/datasheets/1883017.pdf?src-supplier=Element14; ComponentLink5URL: http://www.farnell.com/datasheets/1883017.pdf?src-supplier=Element14; ComponentLink6Description: http://www.ti.com/lit/gpn/ads8860?src-supplier=Mouser; ComponentLink6URL: http://www.ti.com/lit/gpn/ads8860?src-supplier=Mouser; Contact Plating: Gold; Lead Free: Lead Free; Library Name: SensorCard.SchLib; Max Operating Temperature: 85°C; Min Operating Temperature: -40°C; Mount: Surface Mount; Number of Analog Inputs: 1; Number of Inputs: 1; Number of Pins: 10; Packaging: Rail/Tube; Power Dissipation: 5.5mW; Pricing 1: 1=17.63000, 10=16.20200, 80=15.53075, 160=13.68388, 560=12.17275 (USD); REACH SVHC: No SVHC; RoHS: Yes; Stock 1: 558; Supplier 1: Digi-Key; Supplier Part Number 1: 296-47183-ND
U2 = CMP-0272-00853-3: Precision, 20 MHz, 0.9 pA Ib, RRIO, CMOS Operational Amplifier, 1.8 to 5.5 V, -40 to 125 degC, 5-pin SOT23 (DBV5), Green (RoHS & no Sb/Br); Manufacturer: Texas Instruments; Bandwidth: 20MHz; CMRR(Min)(dB): 100; Common Mode Rejection Ratio: 100dB; IIB(Max)(pA): 0.9; Input Bias Current: 0.9pA; Input Offset Voltage (Vos): 150uV; Iq per channel(Max)(mA): 1.75; Max Dual Supply Voltage: 2.75V; Max Supply Voltage: 5.5V; Min Dual Supply Voltage: 900mV; Min Supply Voltage: 1.8V; Nominal Supply Current: 1.45mA; Number of Amplifiers: 1; Number of Channels: 2; Offset Drift(Typ)(uV/C): 1.5; Operating Supply Current: 1.5mA; Output Current: 65mA; Power Supply Rejection Ratio (PSRR): 106.02dB; Slew Rate(Typ)(V/us): 10; Sub Family: High Output Current Amplifier (>=50mA), Low Input Bias Current Amp (<=10pA), Low Noise Amp (<=10nV/rtHz), Low Offset Voltage Amplifier (<=500uV), Low Supply Voltage Amplifier (<=2.7V), Precision Amplifier; Total Supply Voltage(Max)(+5V is 5, +/-5V is 10): 5.5; Total Supply Voltage(Min)(+5V is 5, +/-5V is 10): 1.8; Vio (25C)(Max)(mV): 0.15; Vn at 1kHz(Typ)(nV/rtHz): 8.5; Voltage Gain: 132dB; Case/Package: SOT-23-5; Code_JEDEC: MO-178; Component Kind: Standard; ComponentLink1Description: Manufacturer URL; ComponentLink1URL: http://www.ti.com/; ComponentLink2Description: Datasheet; ComponentLink2URL: http://www.ti.com/general/docs/lit/getliterature.tsp?genericPartNumber=OPA320&fileType=pdf; ComponentLink3Description: Package Specification; ComponentLink3URL: http://www.ti.com/litv/pdf/mpds018i; ComponentLink4Description: https://datasheet.ciiva.com/1799/opa320-1799043.pdf?src-supplier=Digi-Key; ComponentLink4URL: https://datasheet.ciiva.com/1799/opa320-1799043.pdf?src-supplier=Digi-Key; ComponentLink5Description: https://datasheet.ciiva.com/1799/opa320-1799044.pdf?src-supplier=Digi-Key; ComponentLink5URL: https://datasheet.ciiva.com/1799/opa320-1799044.pdf?src-supplier=Digi-Key; ComponentLink6Description: https://datasheet.ciiva.com/1799/opa320-1799045.pdf?src-supplier=Digi-Key; ComponentLink6URL: https://datasheet.ciiva.com/1799/opa320-1799045.pdf?src-supplier=Digi-Key; Contact Plating: Gold; DatasheetVersion: SBOS513D; Eco-Plan: Green (RoHS & no Sb/Br); Gain Bandwidth Product: 20MHz; Lead Free: Lead Free; Library Name: Altium Content Vault; Manufacturer Part Number: OPA320AIDBVR; Max I/O Voltage: 150uV; Max Operating Temperature: 125°C; Min Operating Temperature: -40°C; Mounting Technology: Surface Mount; Number of Circuits: 1; Number of Elements: 1; Number of Pins: 5; Operating Supply Voltage: 2.75V; Output Current per Channel: 65mA; PackageDescription: 5-Pin Plastic Small Outline, Body 1.6 x 2.9 mm, Pitch 0.95 mm; Package Quantity: 3000; PackageReference: DBV0005A; PackageVersion: 4073253-4/K, 03/2006; Packaging: Tape and Reel; PartNumber: OPA320AIDBVR; Pricing 1: 1=2.14000, 10=1.92200, 100=1.54450, 500=1.26896, 1000=1.05142 (USD); Radiation Hardening: No; REACH SVHC: No SVHC; Stock 1: 26460; Supplier 1: Digi-Key; Supplier Part Number 1: 296-29480-1-ND; Unity Gain Bandwidth Product: 20MHz
U3 = CMP-0367-00254-2: Low Noise, Very Low Drift, Precision Voltage Reference, -40 to 125 degC, 8-pin VSSOP (DGK), Green (RoHS & no Sb/Br); Manufacturer: Texas Instruments; Initial Accuracy @ 25/deg C(%): 0.05; Iout/Iz(Max)(mA): 10; Iq(Max)(uA): 1000; Max Input Voltage: 18V; Max Output Current: 10mA; Max Output Voltage: 4.5V; Min Input Voltage: 4.8V; Nominal Supply Current: 800uA; Number of Channels: 1; Quiescent Current: 1mA; Rating: Catalog; Reference Type: Series; Reference Voltage: 5V; SubFamily: Series Voltage Reference; Temp Coeff(Max)(ppm/ degree C): 3; Temperature Coefficient: 3ppm/°C; Tolerance: 0.05%; VI(Max)(V): 18; VI(Min)(V): 5.2; VO(V): 5; Case/Package: VSSOP; Code_JEDEC: MO-187; Component Kind: Standard; ComponentLink1Description: Manufacturer URL; ComponentLink1URL: http://www.ti.com/; ComponentLink2Description: Datasheet; ComponentLink2URL: http://www.ti.com/general/docs/lit/getliterature.tsp?genericPartNumber=REF5045&fileType=pdf; ComponentLink3Description: Package Specification; ComponentLink3URL: http://www.ti.com/litv/pdf/mpds028d; ComponentLink4Description: https://datasheet.ciiva.com/2007/ref5020-2007552.pdf?src-supplier=Digi-Key; ComponentLink4URL: https://datasheet.ciiva.com/2007/ref5020-2007552.pdf?src-supplier=Digi-Key; ComponentLink5Description: https://datasheet.ciiva.com/26180/ref5050-26180982.pdf?src-supplier=Avnet+Europe; ComponentLink5URL: https://datasheet.ciiva.com/26180/ref5050-26180982.pdf?src-supplier=Avnet+Europe; ComponentLink6Description: https://datasheet.ciiva.com/22666/1885920-22666106.pdf?src-supplier=Element14; ComponentLink6URL: https://datasheet.ciiva.com/22666/1885920-22666106.pdf?src-supplier=Element14; ComponentLink7Description: https://datasheet.ciiva.com/2007/ref5020-2007553.pdf?src-supplier=Digi-Key; ComponentLink7URL: https://datasheet.ciiva.com/2007/ref5020-2007553.pdf?src-supplier=Digi-Key; ComponentLink8Description: https://datasheet.ciiva.com/2007/ref5020-2007554.pdf?src-supplier=Digi-Key; ComponentLink8URL: https://datasheet.ciiva.com/2007/ref5020-2007554.pdf?src-supplier=Digi-Key; ComponentLink9Description: https://datasheet.ciiva.com/14197/ref5050-14197087.pdf?src-supplier=Avnet; ComponentLink9URL: https://datasheet.ciiva.com/14197/ref5050-14197087.pdf?src-supplier=Avnet; DatasheetVersion: SBOS410E; Eco-Plan: Green (RoHS & no Sb/Br); Height: 0.95mm; Lead Free: Lead Free; Length: 3.1mm; Library Name: Altium Content Vault; Manufacturer Part Number: REF5045IDGKT; Max Operating Temperature: 125°C; Min Operating Temperature: -40°C; Mounting Technology: Surface Mount; Number of Pins: 8; Output Current: 10mA; Output Voltage: 4.5V; PackageDescription: 8-Pin Thin Small Outline Package 3 x 3 mm Body ,0.65 mm Pitch; Package Quantity: 1; PackageReference: DGK0008A; PackageVersion: 4073329/E; Packaging: Tape and Reel; PartNumber: REF5045IDGKT; Pricing 1: 1=6.80000, 10=6.14500, 100=5.08770 (USD); Radiation Hardening: No; REACH SVHC: No SVHC; RoHS: Yes; Stock 1: 5147; Supplier 1: Digi-Key; Supplier Part Number 1: 296-24505-1-ND; Weight: 0.00067oz; Width: 3.1mm
*1 = TitleBlockWEMPEC: Component Kind: Standard; Library Name: SensorCard.SchLib
IC1 = LA 55-P: Component Kind: Standard; Description: LA 55-P; Digikey Part no.: 398-1010-ND; Library Name: SensorCard.SchLib
